# Altium schematic sheet laser_power_sw.SchDoc
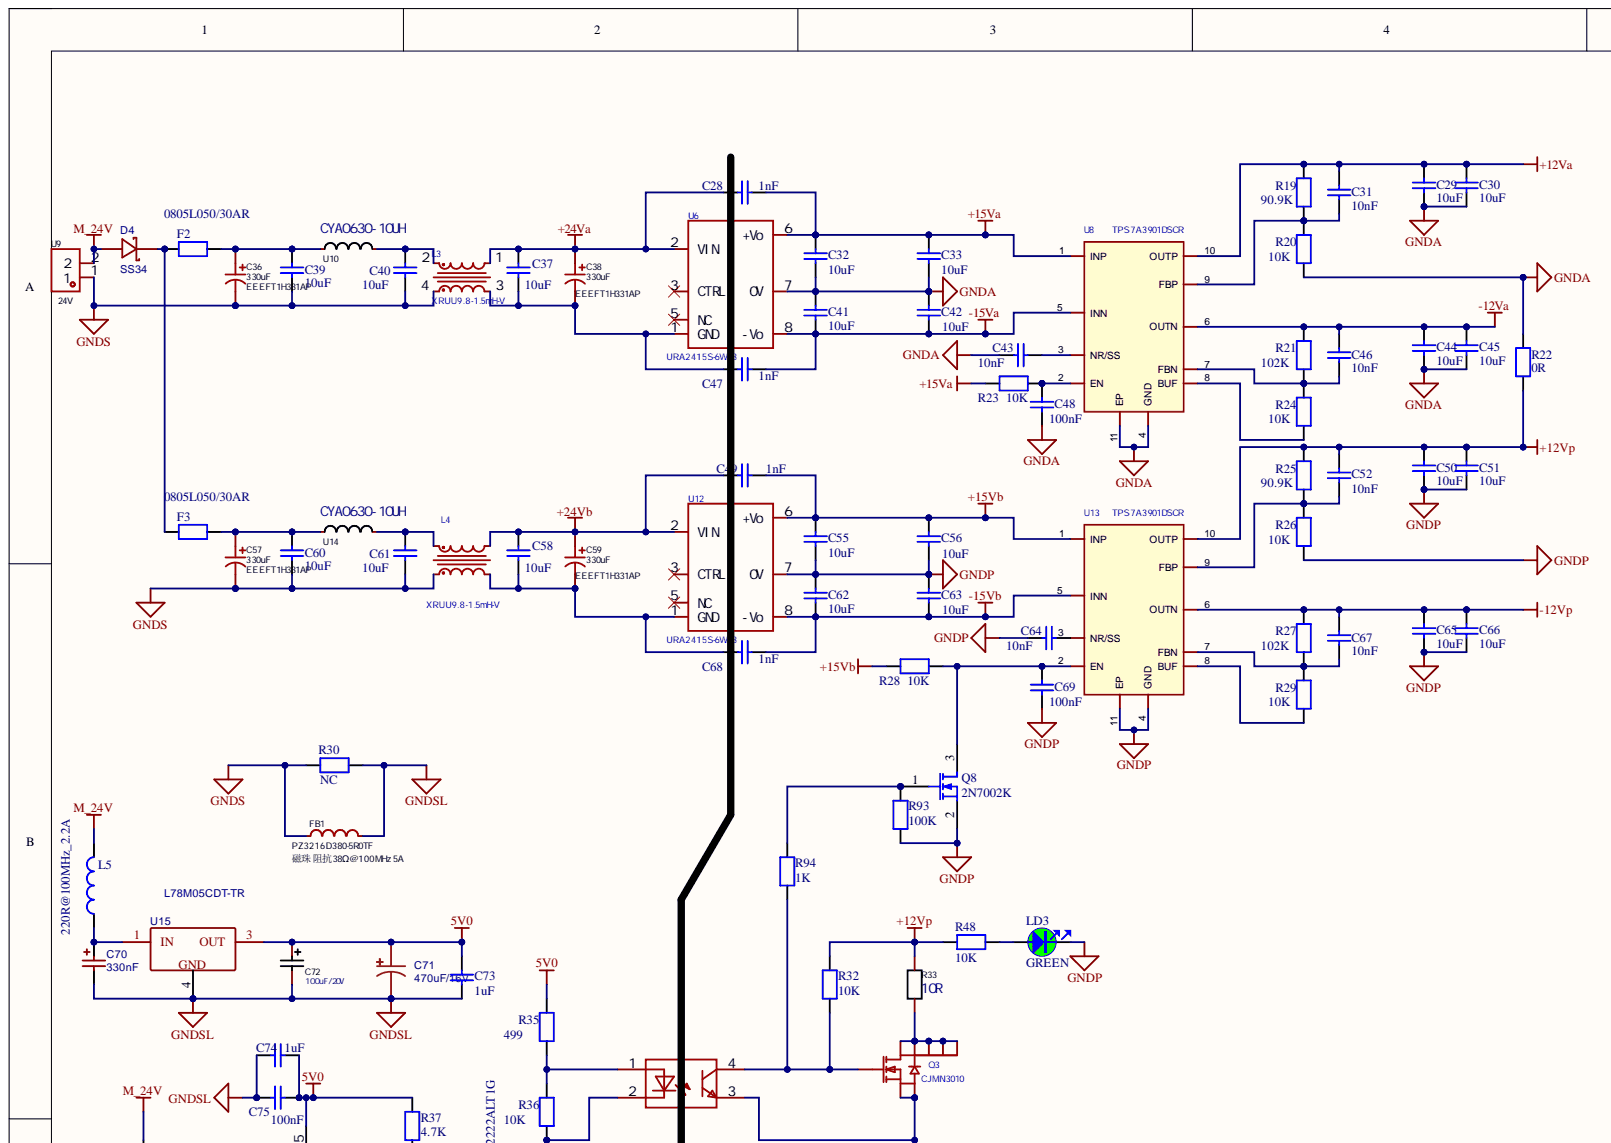
[diagram p0: laser_power_sw.SchDoc, top left]
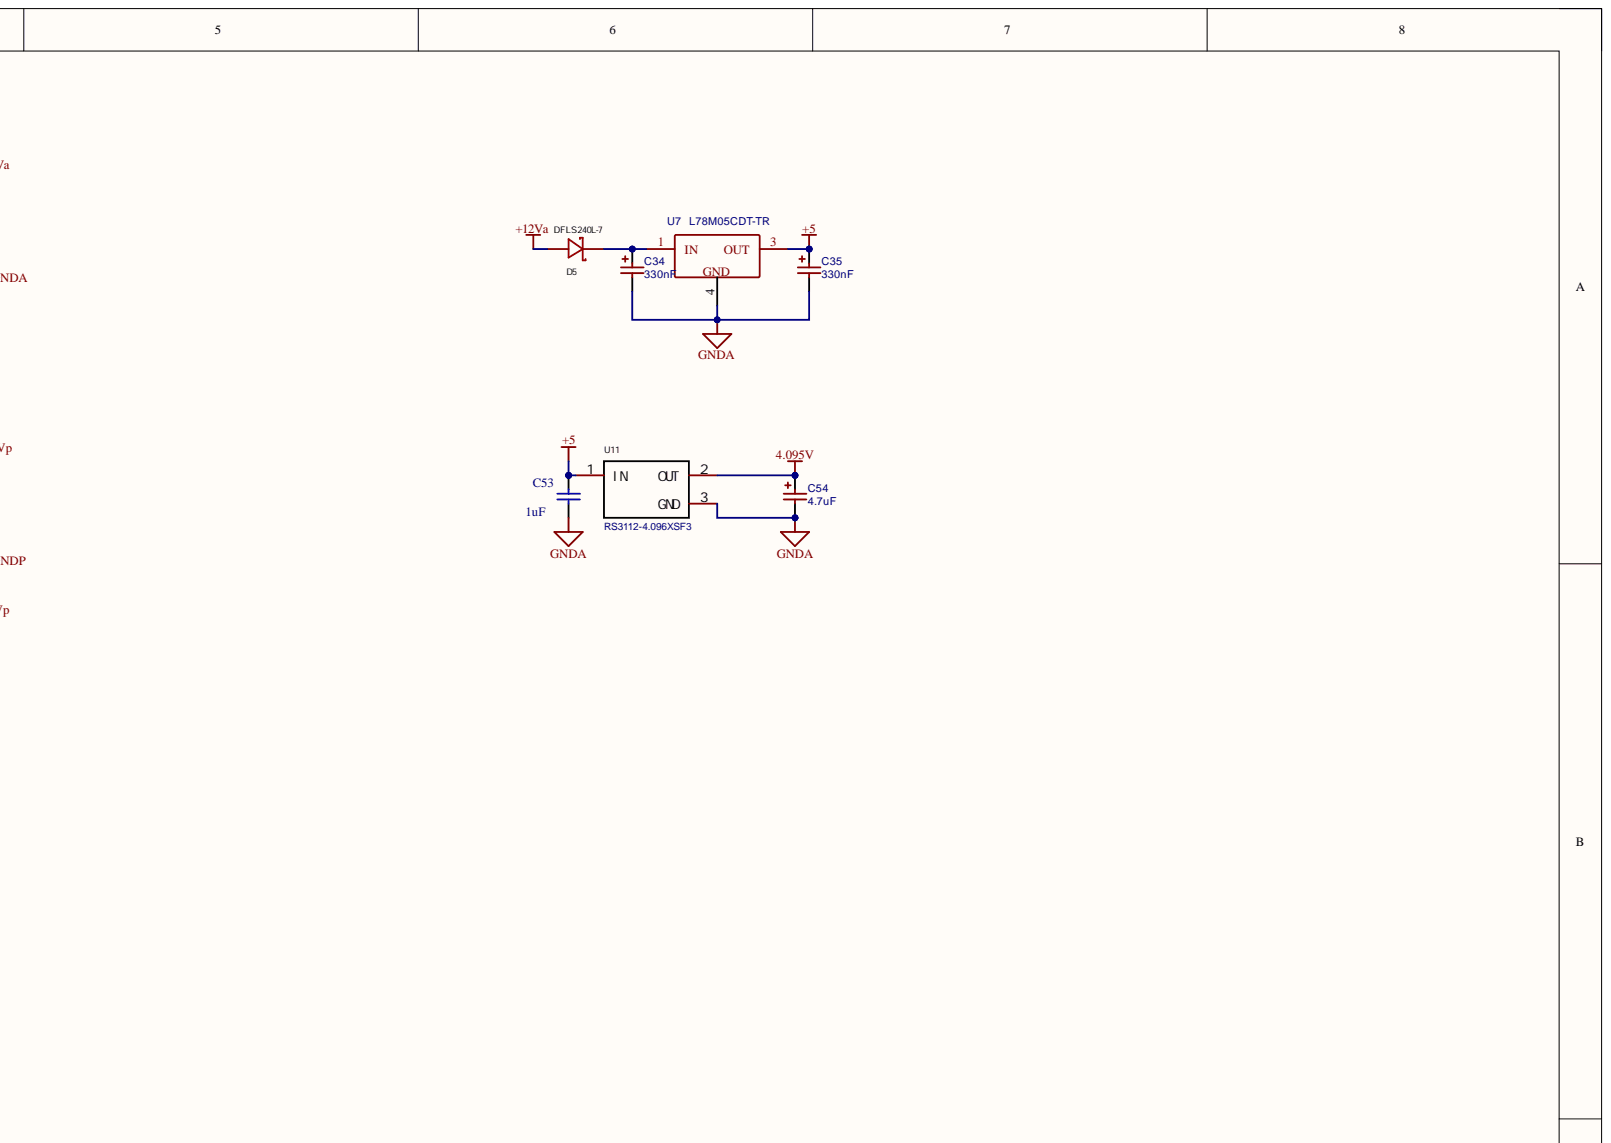
[diagram p1: laser_power_sw.SchDoc, top right]
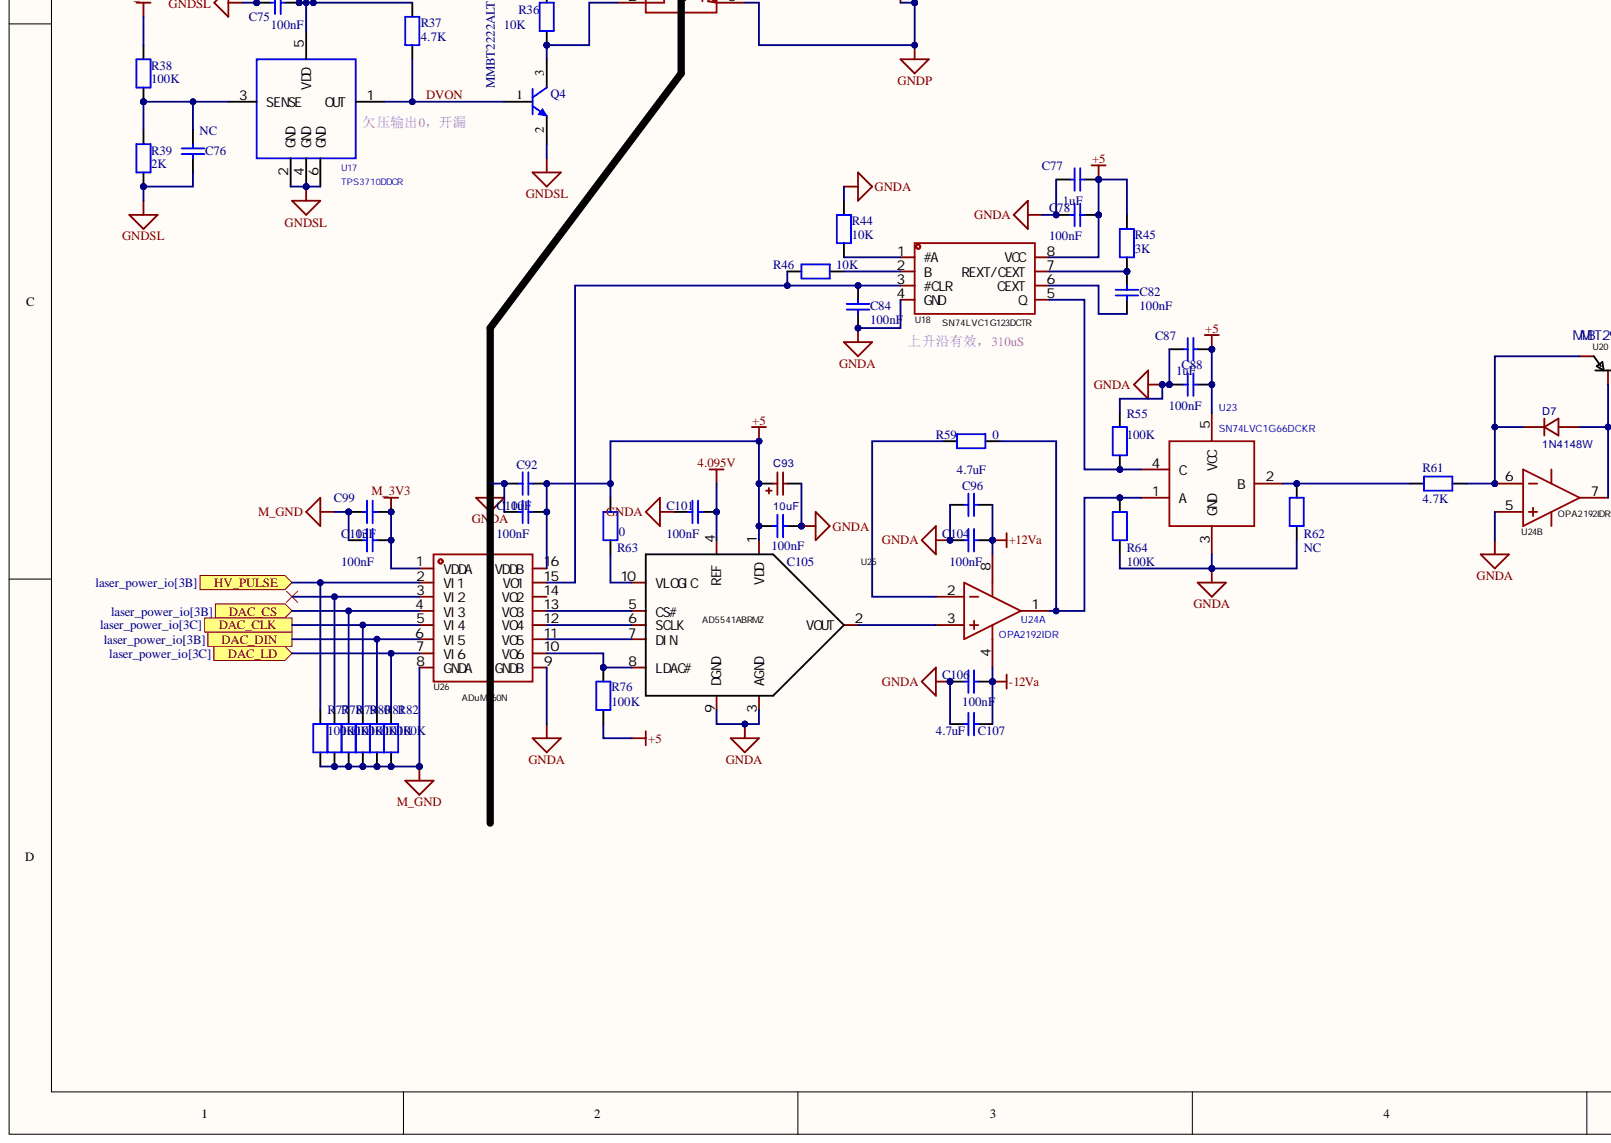
[diagram p2: laser_power_sw.SchDoc, bottom left]
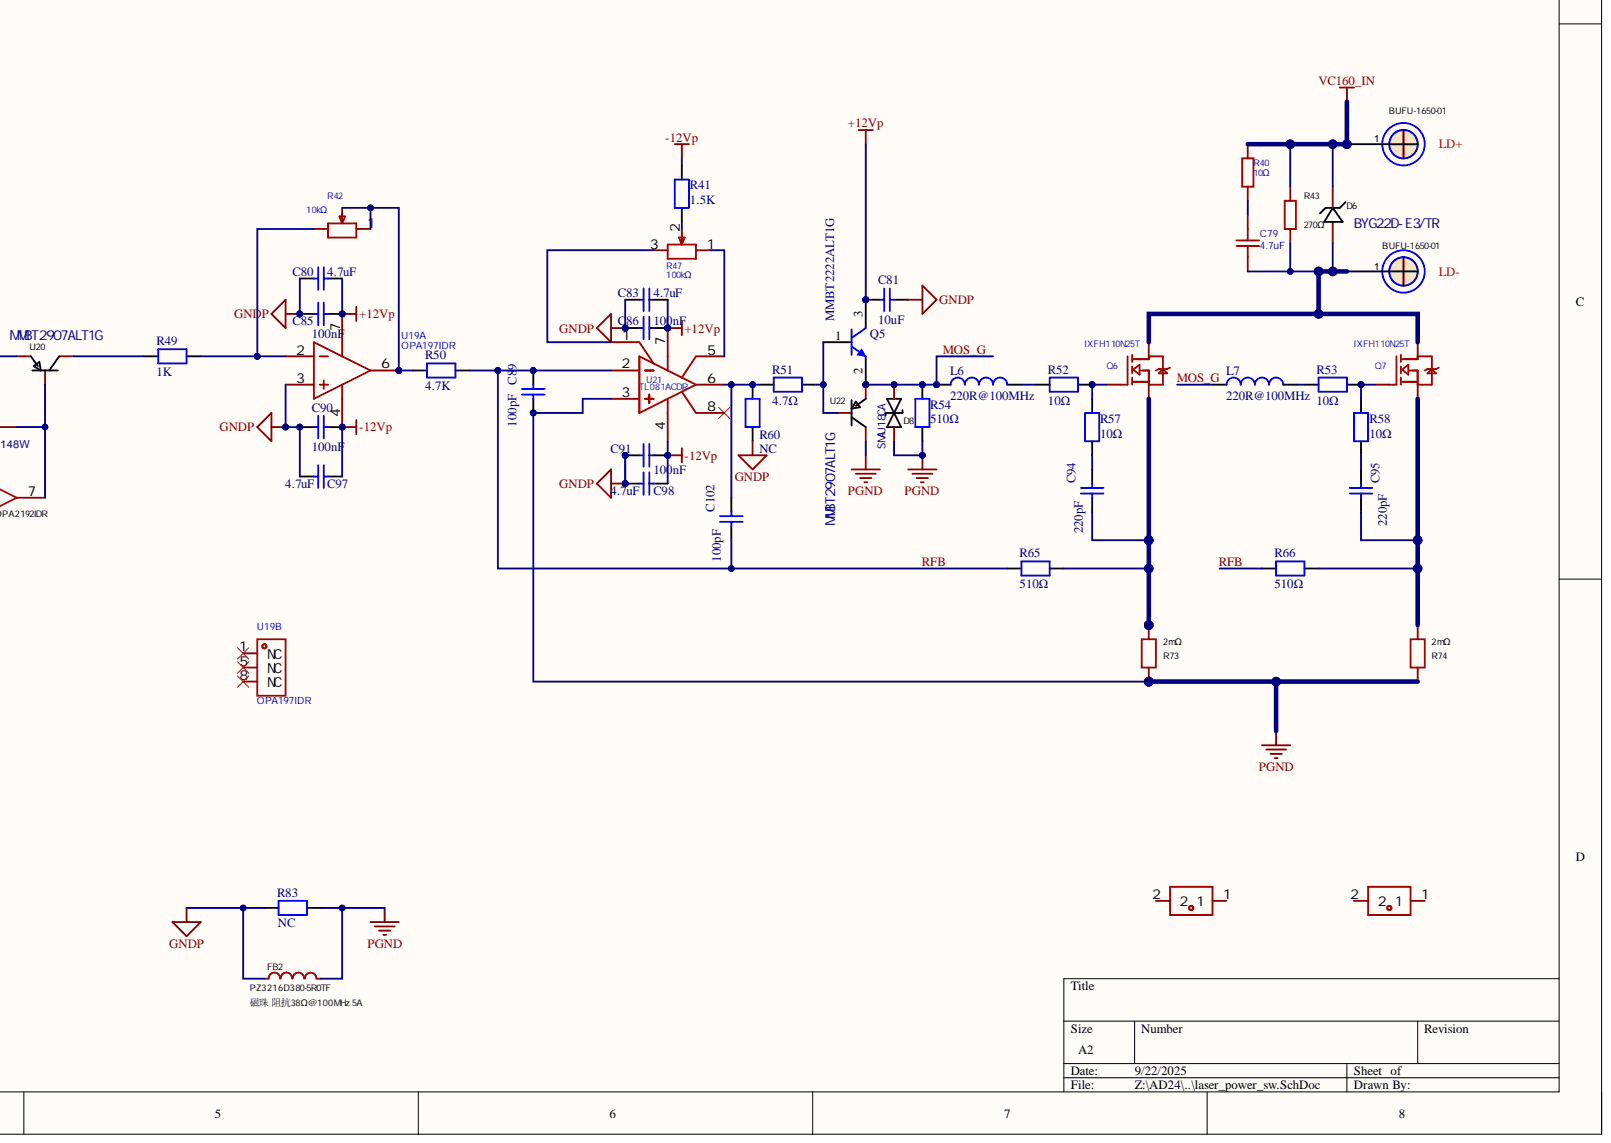
[diagram p3: laser_power_sw.SchDoc, bottom right]

## Parts
C28  1nF  [1206]
C29  10uF  [C1210]
C30  10uF  [C1210]
C31  10nF  [C0603]
C32  10uF  [C1210]
C33  10uF  [C1210]
C34  330nF  [CAP-SMD_L3.2-W1.6-RD-C7171]
C35  330nF  [CAP-SMD_L3.2-W1.6-RD-C7171]
C36  EEEFT1H331AP  value 330uF  [CAP-SMD_BD10.0-L10.3-W10.3-LS10.9-FD]
C37  10uF  [1206]
C38  EEEFT1H331AP  value 330uF  [CAP-SMD_BD10.0-L10.3-W10.3-LS10.9-FD]
C39  10uF  [1206]
C40  10uF  [1206]
C41  10uF  [C1210]
C42  10uF  [C1210]
C43  10nF  [C0603]
C44  10uF  [C1210]
C45  10uF  [C1210]
C46  10nF  [C0603]
C47  1nF  [1206]
C48  100nF  [C0603]
C49  1nF  [1206]
C50  10uF  [C1210]
C51  10uF  [C1210]
C52  10nF  [C0603]
C53  1uF  [0603L]
C54  4.7uF  [CAP-SMD_L3.2-W1.6-RD-C7171]
C55  10uF  [C1210]
C56  10uF  [C1210]
C57  EEEFT1H331AP  value 330uF  [CAP-SMD_BD10.0-L10.3-W10.3-LS10.9-FD]
C58  10uF  [1206]
C59  EEEFT1H331AP  value 330uF  [CAP-SMD_BD10.0-L10.3-W10.3-LS10.9-FD]
C60  10uF  [1206]
C61  10uF  [1206]
C62  10uF  [C1210]
C63  10uF  [C1210]
C64  10nF  [C0603]
C65  10uF  [C1210]
C66  10uF  [C1210]
C67  10nF  [C0603]
C68  1nF  [1206]
C69  100nF  [C0603]
C70  330nF  [CAP-SMD_L3.2-W1.6-RD-C7171]
C71  470uF/16V  [CAP-SMD_BD6.3-L6.6-W6.6-LS7.6-FD]
C72  100uF/20V  [CAP-SMD_L7.3-W4.3-R-RD]
C73  1uF  [C0603]
C74  1uF  [0603L]
C75  100nF  [C0402]
C76  NC  [C0402]
C77  1uF  [0603L]
C78  100nF  [0603L]
C79  4.7uF  [CAP-TH_L26.5-W11.0-P22.50-D1.4]
C80  4.7uF  [C1206]
C81  10uF  [C1210]
C82  100nF  [C1210]
C83  4.7uF  [C1206]
C84  100nF  [0603L]
C85  100nF  [0603L]
C86  100nF  [0603L]
C87  1uF  [0603L]
C88  100nF  [0603L]
C89  100pF  [0603L]
C90  100nF  [0603L]
C91  100nF  [0603L]
C92  1uF  [0603L]
C93  10uF  [CAP-SMD_L3.2-W1.6-RD-C7171]
C94  220pF  [0603L]
C95  220pF  [0603L]
C96  4.7uF  [C1206]
C97  4.7uF  [C1206]
C98  4.7uF  [C1206]
C99  1uF  [0603L]
C100  100nF  [0603L]
C101  100nF  [0603L]
C102  100pF  [0603L]
C103  100nF  [0603L]
C104  100nF  [0603L]
C105  100nF  [0603L]
C106  100nF  [0603L]
C107  4.7uF  [C1206]
CN1  BUFU-1650-01  [M5_BUFU]
CN2  BUFU-1650-01  [M5_BUFU]
D4  SS34  [DO-214AC_L4.3-W2.7-LS5.3-RD]
D5  DFLS240L-7  [POWERDI-123_L2.8-W1.8-LS3.7-RD]
D6  BYG22D-E3/TR  [SMB_L4.6-W3.6-LS5.3-RD]
D7  1N4148W  [SOD-123_L2.8-W1.8-LS3.7-RD]
D8  SMAJ18CA  [SMA_L4.4-W2.6-LS5.0-BI]
F2  0805L050/30AR  [0805]
F3  0805L050/30AR  [0805]
FB1  PZ3216D380-5R0TF  [L1206]
FB2  PZ3216D380-5R0TF  [L1206]
L3  XRUU9.8-1.5mH-V  [FILTER-TH_4P-L16.5-W11.5_YDUU9.8-603L0201]
L4  XRUU9.8-1.5mH-V  [FILTER-TH_4P-L16.5-W11.5_YDUU9.8-603L0201]
L5  220R@100MHz_2.2A  [L0603]
L6  value 220R@100MHz  [L0603]  (hidden comment: MPZ1608S221ATD25)
L7  value 220R@100MHz  [L0603]  (hidden comment: MPZ1608S221ATD25)
LD3  value GREEN  [LED_0603_GREEN]  (hidden comment: LED_green)
Q3  CJMN3010  [DFNWB-6_L2.0-W2.0-P0.65-BL-EP_CJ_CJMNT33]
Q4  MMBT2222ALT1G  [NPN_SOT23]
Q5  MMBT2222ALT1G  [NPN_SOT23]
Q6  IXFH110N25T  [TO-247AC-3_L15.8-W5.0-P5.46-L]
Q7  IXFH110N25T  [TO-247AC-3_L15.8-W5.0-P5.46-L]
Q8  2N7002K  [SOT-23(SOT-23-3)]
R19  90.9K  [R0603]
R20  10K  [R0603]
R21  102K  [R0603]
R22  0R  [1206]
R23  10K  [R0603]
R24  10K  [R0603]
R25  90.9K  [R0603]
R26  10K  [R0603]
R27  102K  [R0603]
R28  10K  [R0603]
R29  10K  [R0603]
R30  NC  [1206]
R32  10K  [R0603]
R33  10R  [R2512]
R35  499  [0805]
R36  10K  [R0603]
R37  4.7K  [R0603]
R38  100K  [R0603]
R39  2K  [R0603]
R40  10Ω  [RES-TH_L13.0-W9.5-P4.50-D0.7]
R41  1.5K  [R0603]
R42  10kΩ  [RES-ADJ-SMD_3224W]
R43  270Ω  [RES-TH_BD9.0-L31.5-P35.50-D0.8]
R44  10K  [R0603]
R45  3K  [R0603]
R46  10K  [R0603]
R47  100kΩ  [RES-ADJ-SMD_3224W]
R48  10K  [R0603]
R49  1K  [R0603]
R50  4.7K  [R0603]
R51  4.7Ω  [0805]
R52  10Ω  [0805]
R53  10Ω  [0805]
R54  510Ω  [1206]
R55  100K  [R0603]
R57  10Ω  [0805]
R58  10Ω  [0805]
R59  0  [R0603]
R60  NC  [R0603]
R61  4.7K  [R0603]
R62  NC  [R0603]
R63  0  [R0603]
R64  100K  [R0603]
R65  510Ω  [R0603]
R66  510Ω  [R0603]
R73  2mΩ  [R5930]
R74  2mΩ  [R5930]
R76  100K  [R0603]
R77  100K  [R0603]
R78  100K  [R0603]
R79  100K  [R0603]
R80  100K  [R0603]
R81  100K  [R0603]
R82  100K  [R0603]
R83  NC  [1206]
R93  100K  [R0603]
R94  1K  [R0603]
U6  URA2415S-6WR3  [PWRM-TH_7P-L22.0-W9.5-P2.54-WRE1205S-3WR2]
U7  L78M05CDT-TR  [TO-252-2_L6.5-W6.1-P4.58-LS10.0-TL]
U8  TPS7A3901DSCR  [WSON-10_L3.0-W3.0-P0.50-BL-EP]
U9  24V  [CONN-TH_2P-P3.96_DF63M-2P-3.96DSA]
U10  CYA0630-10UH  [IND-SMD_L7.2-W6.6_GPSR07X0]
U11  RS3112-4.096XSF3  [SOT-23-3_L2.9-W1.6-P1.90-LS2.8-BR]
U12  URA2415S-6WR3  [PWRM-TH_7P-L22.0-W9.5-P2.54-WRE1205S-3WR2]
U13  TPS7A3901DSCR  [WSON-10_L3.0-W3.0-P0.50-BL-EP]
U14  CYA0630-10UH  [IND-SMD_L7.2-W6.6_GPSR07X0]
U15  L78M05CDT-TR  [TO-252-2_L6.5-W6.1-P4.58-LS10.0-TL]
U16  EL817S1(C)(TU)-F  [OPTO-SMD-4_L4.6-W6.5-P2.54-LS10.3-TL]  (value not drawn)
U17  TPS3710DDCR  [TSOT-23-6_L2.9-W1.6-P0.95-LS2.8-BR]
U18  SN74LVC1G123DCTR  [SM8_L3.2-W2.9-P0.65-LS4.3-BR]
U19  OPA197IDR  [SOIC-8_L4.9-W3.9-P1.27-LS6.0-BL]  (parts A,B)
U20  MMBT2907ALT1G  [SOT-23-3_L3.0-W1.7-P0.95-LS2.9-BR]
U21  TL081ACDR  [SOP-8_L4.9-W3.9-P1.27-LS6.0-BL]
U22  MMBT2907ALT1G  [SOT-23-3_L3.0-W1.7-P0.95-LS2.9-BR]
U23  SN74LVC1G66DCKR  [SC-70-5_L2.1-W1.3-P0.65-LS2.1-TL]
U24  OPA2192IDR  [SOIC-8_L4.9-W3.9-P1.27-LS6.0-BL]  (parts A,B)
U25  AD5541ABRMZ  [MSOP-10_L3.0-W3.0-P0.50-LS4.9-BL]
U26  ADuM160N  [SOIC-16_L9.9-W3.9-P1.27-LS6.0-BL]
U27  35*12*25  [HEATSINK-TH_L34.2-W12.5-P25.40]  (value not drawn)
U28  35*12*25  [HEATSINK-TH_L34.2-W12.5-P25.40]  (value not drawn)

## Ports
DAC_CLK, DAC_CS, DAC_DIN, DAC_LD, HV_PULSE

## Nets
4.095V: C54.1 C101.1 U11.2 U25.4(REF)
5V0: C35.1 C53.1 C71.1 C72.1 C73.2 C74.2 C75.1 C77.2 C78.1 C87.2 C88.1 C92.2 C93.1 C100.1 C105.2 R35.1 R37.1 R45.2 R63.2 R76.1 U7.3 U11.1 U15.3 U17.5(VDD) U18.8(VCC) U23.5(VCC) U25.1(VDD) U26.16(VDDB)
+12Va: C29.2 C30.2 C31.1 C96.2 C104.1 D5.2 R19.1 U8.10(OUTP) U24.8
+12Vp: C50.2 C51.2 C52.1 C80.2 C81.2 C83.2 C85.1 C86.1 Q5.3 R22.1 R25.1 R32.1 R33.2 R48.1 U13.10(OUTP) U19.7 U21.7
+15Va: C28.2 C32.2 C33.2 R23.1 U6.6(+Vo) U8.1(INP)
+15Vb: C49.2 C55.2 C56.2 R28.1 U12.6(+Vo) U13.1(INP)
+24Va: C28.1 C37.1 C38.1 L3.1 U6.2(VIN)
+24Vb: C49.1 C58.1 C59.1 L4.1 U12.2(VIN)
-12Va: C44.2 C45.2 C46.1 C106.1 C107.2 R21.1 U8.6(OUTN) U24.4
-12Vp: C65.2 C66.2 C67.1 C90.1 C91.1 C97.2 C98.2 R27.1 R41.1 U13.6(OUTN) U19.4 U21.4
-15Va: C41.1 C42.1 C47.2 U6.8(-Vo) U8.5(INN)
-15Vb: C62.1 C63.1 C68.2 U12.8(-Vo) U13.5(INN)
GNDA: C29.1 C30.1 C32.1 C33.1 C34.2 C35.2 C41.2 C42.2 C43.2 C44.1 C45.1 C48.2 C53.2 C54.2 C77.1 C78.2 C84.2 C87.1 C88.2 C92.1 C93.2 C96.1 C100.2 C101.2 C104.2 C105.1 C106.2 C107.1 R20.1 R22.2 R44.2 R55.1 R62.2 R64.2 U6.7(0V) U7.4 U8.4(GND) U8.11(EP) U11.3 U18.4(GND) U23.3(GND) U24.5 U25.3(AGND) U25.9(DGND) U26.9(GNDB)
GNDP: C50.1 C51.1 C55.1 C56.1 C62.2 C63.2 C64.2 C65.1 C66.1 C69.2 C80.1 C81.1 C83.1 C85.2 C86.2 C90.2 C91.2 C97.1 C98.1 FB2.1 LD3.2 Q3.4 Q3.8 Q8.2 R26.1 R60.2 R83.1 R93.2 U12.7(0V) U13.4(GND) U13.11(EP) U16.3 U19.3
GNDS: C36.2 C39.2 C40.2 C57.2 C60.2 C61.2 FB1.1 L3.4 L4.4 R30.1 U9.1
GNDSL: C70.2 C71.2 C72.2 C73.1 C74.1 C75.2 C76.2 FB1.2 Q4.2 R30.2 R39.2 U15.4 U17.2(GND) U17.4(GND) U17.6(GND)
M_3V3: C99.2 C103.1 U26.1(VDDA)
M_24V: D4.2 L5.1 R38.1 U9.2
M_GND: C99.1 C103.2 R77.2 R78.2 R79.2 R80.2 R81.2 R82.2 U26.8(GNDA)
PGND: C89.2 D8.1 FB2.2 R54.1 R73.1 R74.1 R83.2 U21.3 U22.3
VC160_IN: CN1.1 D6.1 R40.1 R43.2
DAC_CLK: R80.1 U26.5(VI4) ; port DAC_CLK
DAC_CS: R79.1 U26.4(VI3) ; port DAC_CS
DAC_DIN: R81.1 U26.6(VI5) ; port DAC_DIN
DAC_LD: R82.1 U26.7(VI6) ; port DAC_LD
DVON: Q4.1 R37.2 U17.1(OUT)
HV_PULSE: R77.1 U26.2(VI1) ; port HV_PULSE
MOS_G: D8.2 L6.1 L7.1 Q5.2 R54.2 U22.2
RFB: C89.1 C102.2 R50.1 R65.2 R66.2 U21.2
NetC31_2: C31.2 R19.2 R20.2 U8.9(FBP)
NetC34_1: C34.1 D5.1 U7.1
NetC36_1: C36.1 C39.1 F2.2 U10.1
NetC37_2: C37.2 C38.2 C47.1 L3.3 U6.1(GND)
NetC40_1: C40.1 L3.2 U10.2
NetC43_1: C43.1 U8.3(NR/SS)
NetC46_2: C46.2 R21.2 R24.2 U8.7(FBN)
NetC48_1: C48.1 R23.2 U8.2(EN)
NetC52_2: C52.2 R25.2 R26.2 U13.9(FBP)
NetC57_1: C57.1 C60.1 F3.2 U14.1
NetC58_2: C58.2 C59.2 C68.1 L4.3 U12.1(GND)
NetC61_1: C61.1 L4.2 U14.2
NetC64_1: C64.1 U13.3(NR/SS)
NetC67_2: C67.2 R27.2 R29.2 U13.7(FBN)
NetC69_1: C69.1 Q8.3 R28.2 U13.2(EN)
NetC70_1: C70.1 L5.2 U15.1
NetC76_1: C76.1 R38.2 R39.1 U17.3(SENSE)
NetC79_1: C79.1 CN2.1 D6.2 Q6.2 Q7.2 R43.1
NetC79_2: C79.2 R40.2
NetC82_1: C82.1 U18.6
NetC82_2: C82.2 R45.1 U18.7(REXT/CEXT)
NetC84_1: C84.1 R46.2 U18.3(#CLR) U26.15(VO1)
NetC94_1: C94.1 R57.1
NetC94_2: C94.2 Q6.3 R65.1 R73.2
NetC95_1: C95.1 R58.1
NetC95_2: C95.2 Q7.3 R66.1 R74.2
NetC102_1: C102.1 R51.1 R60.1 U21.6
NetD4_1: D4.1 F2.1 F3.1
NetD7_1: D7.1 R61.1 U20.2 U24.6
NetD7_2: D7.2 U20.1 U24.7
NetL6_2: L6.2 R52.1
NetL7_2: L7.2 R53.1
NetLD3_1: LD3.1 R48.2
NetQ3_1: Q3.1 Q3.2 Q3.5 Q3.6 Q3.7 R33.1
NetQ3_3: Q3.3 R32.2 R94.2 U16.4
NetQ4_3: Q4.3 R36.2 U16.2
NetQ5_1: Q5.1 R51.2 U22.1
NetQ6_1: Q6.1 R52.2 R57.2
NetQ7_1: Q7.1 R53.2 R58.2
NetQ8_1: Q8.1 R93.1 R94.1
NetR24_1: R24.1 U8.8(BUF)
NetR29_1: R29.1 U13.8(BUF)
NetR35_2: R35.2 R36.1 U16.1
NetR41_2: R41.2 R47.2
NetR42_1: R42.1 R42.2 R50.2 U19.6
NetR42_3: R42.3 R49.1 U19.2
NetR44_1: R44.1 U18.1(#A)
NetR46_1: R46.1 U18.2(B)
NetR47_1: R47.1 U21.5
NetR47_3: R47.3 U21.1
NetR49_2: R49.2 U20.3
NetR55_2: R55.2 U18.5(Q) U23.4(C)
NetR59_1: R59.1 R64.1 U23.1(A) U24.1
NetR59_2: R59.2 U24.2
NetR61_2: R61.2 R62.1 U23.2(B)
NetR63_1: R63.1 U25.10(VLOGIC)
NetR76_2: R76.2 U25.8(LDAC#) U26.10(VO6)
NetR78_1: R78.1 U26.3(VI2)
NetU24_3: U24.3 U25.2(VOUT)
NetU25_5: U25.5(CS#) U26.13(VO3)
NetU25_6: U25.6(SCLK) U26.12(VO4)
NetU25_7: U25.7(DIN) U26.11(VO5)

Not connected: U6 3(CTRL) 5(NC); U12 3(CTRL) 5(NC); U19 1(NC) 5(NC) 8(NC); U21 8; U26 14(VO2); U27 1 2; U28 1 2
